# Altium schematic sheet ESP_Grow_Main_Rev_A_2_3V_5V.SchDoc(ESP_Grow_Main_Rev_A_2_3V_5V)
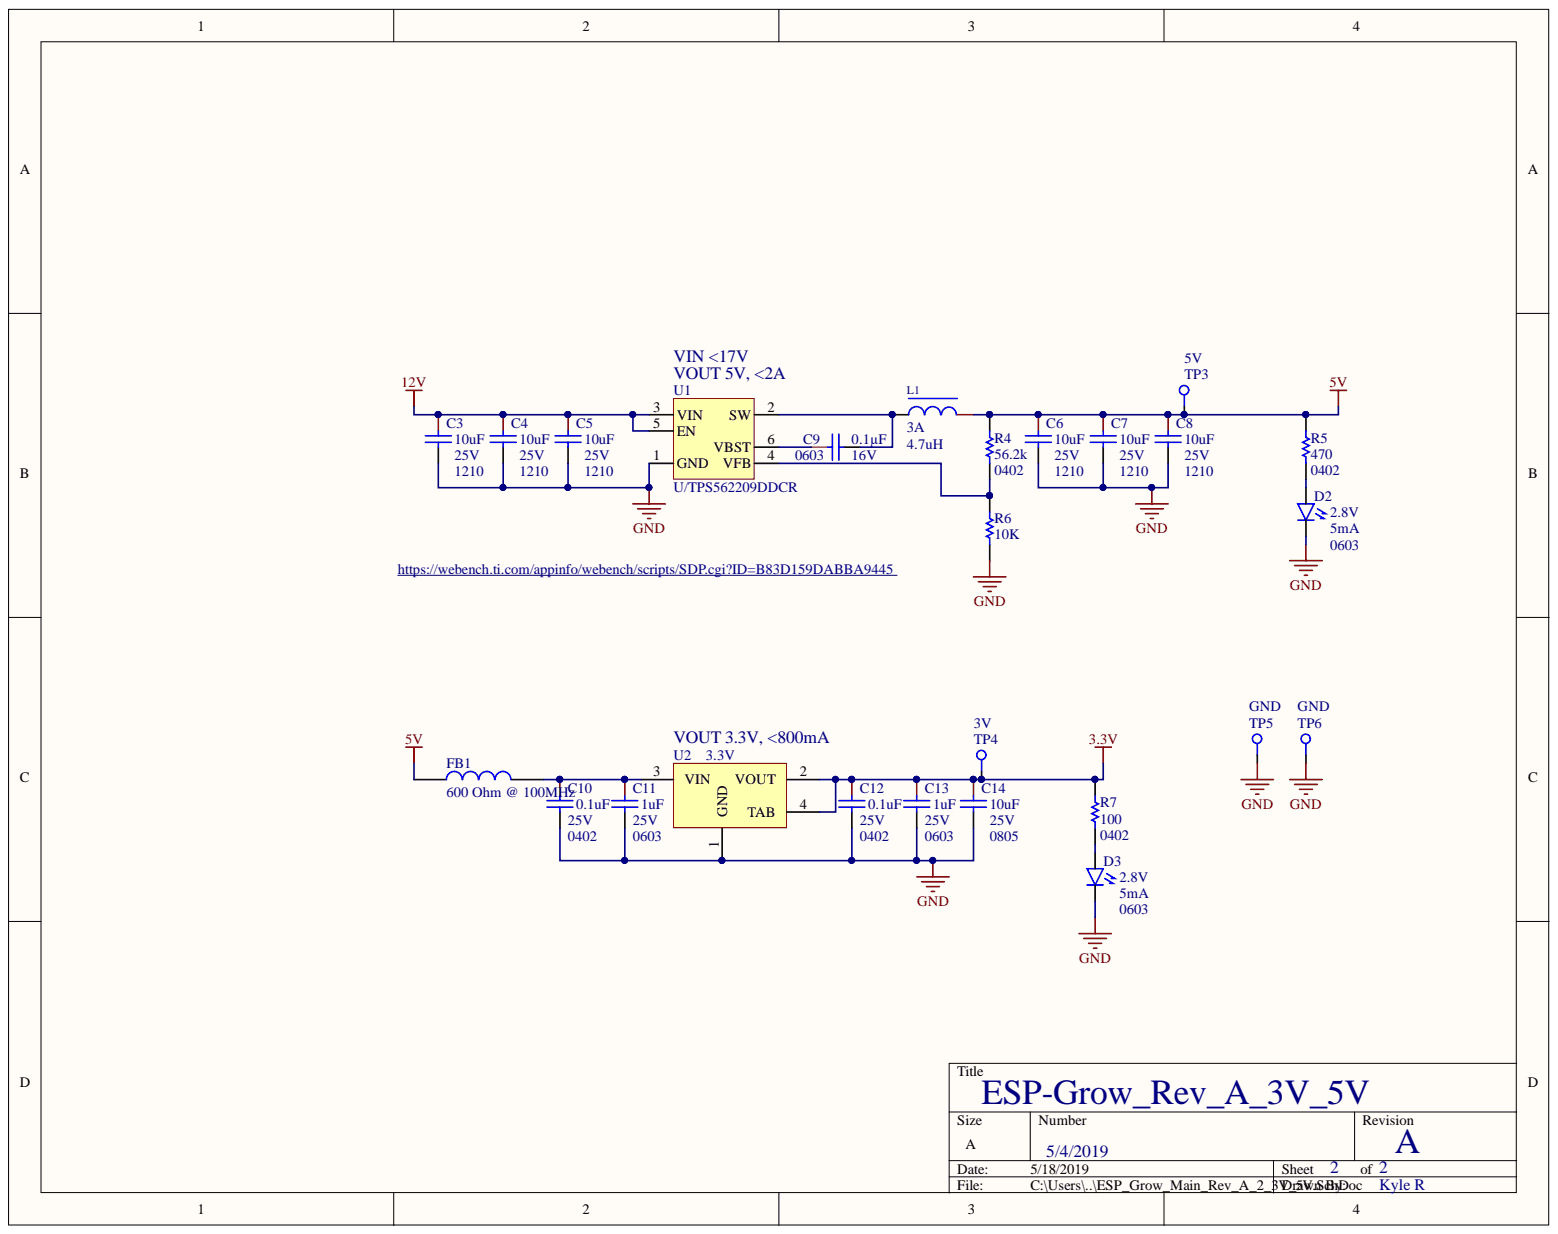
[diagram p0: ESP_Grow_Main_Rev_A_2_3V_5V.SchDoc]

## Parts
C3  10uF  Voltage Rated: 25V  Package / Case: 1210  [CAPC1210(3225)_N]
C4  10uF  Voltage Rated: 25V  Package / Case: 1210  [CAPC1210(3225)_N]
C5  10uF  Voltage Rated: 25V  Package / Case: 1210  [CAPC1210(3225)_N]
C6  10uF  Voltage Rated: 25V  Package / Case: 1210  [CAPC1210(3225)_N]
C7  10uF  Voltage Rated: 25V  Package / Case: 1210  [CAPC1210(3225)_N]
C8  10uF  Voltage Rated: 25V  Package / Case: 1210  [CAPC1210(3225)_N]
C9  Voltage - Rated: 16V  Package / Case: 0603  Capacitance: 0.1µF  [CAPC0603(1608)_L]  (hidden comment: 0.1uF)
C10  0.1uF  Voltage - Rated: 25V  Package / Case: 0402  [CAPC0402(1005)_N]
C11  1uF  Voltage - Rated: 25V  Package / Case: 0603  [CAPC0603(1608)_N]
C12  0.1uF  Voltage - Rated: 25V  Package / Case: 0402  [CAPC0402(1005)_N]
C13  1uF  Voltage - Rated: 25V  Package / Case: 0603  [CAPC0603(1608)_N]
C14  10uF  Voltage - Rated: 25V  Package / Case: 0805  [CAPC0805(2012)_L]
D2  Voltage - Forward (Vf) (Typ): 2.8V  Package / Case: 0603  Current - Test: 5mA  [D/0603]  (hidden comment: D/LEDB/0603)
D3  Voltage - Forward (Vf) (Typ): 2.8V  Package / Case: 0603  Current - Test: 5mA  [D/0603]  (hidden comment: D/LEDB/0603)
FB1  600 Ohm @ 100MHz  [RESC0805(2012)_N]
L1  4.7uH  Current Rating (Amps): 3A  [INDP6060X45N]
R4  Supplier Device Package: 0402  Resistance (Ohms): 56.2k  [RESC0402(1005)_N]  (hidden comment: 56.2K 1%)
R5  470  Supplier Device Package: 0402  [RESC0402(1005)_N]
R6  10K  [RESC0402(1005)_N]
R7  100  Supplier Device Package: 0402  [RESC0402(1005)_N]
TP3  5V  [Pogo-Testpoint]  (no BOM)
TP4  3V  [Pogo-Testpoint]  (no BOM)
TP5  GND  [Pogo-Testpoint]  (no BOM)
TP6  GND  [Pogo-Testpoint]  (no BOM)
U1  U/TPS562209DDCR  [SOT-23]
U2  3.3V  [DSO-G3]

## Nets
3.3V: C12.1 C13.1 C14.1 R7.2 TP4.1 U2.2(VOUT) U2.4(TAB)
5V: C6.1 C7.1 C8.1 FB1.1 L1.1 R4.2 R5.2 TP3.1
12V: C3.1 C4.1 C5.1 U1.3(VIN) U1.5(EN)
GND: C3.2 C4.2 C5.2 C6.2 C7.2 C8.2 C10.2 C11.2 C12.2 C13.2 C14.2 D2.1 D3.1 R6.1 TP5.1 TP6.1 U1.1(GND) U2.1(GND)
NetC9_1: C9.1 U1.6(VBST)
NetC9_2: C9.2 L1.2 U1.2(SW)
NetC10_1: C10.1 C11.1 FB1.2 U2.3(VIN)
NetD2_2: D2.2 R5.1
NetD3_2: D3.2 R7.1
NetR4_1: R4.1 R6.2 U1.4(VFB)

## Library data of the parts
C3-C8 = CAP CER 10UF 25V JB 1210: 10uF 1210 25V; Manufacturer Part Number: C3225JB1E106K250AA; Manufacturer: TDK Corporation; Tolerance: ±10%; Temperature Coefficient: JB; Notification: Current market demand for these product types have resulted in fluctuating and extending lead times. Lead times may differ.; Voltage - Rated: 25V; Thickness (Max): 0.110" (2.80mm); Supplier Part Number 1: 445-11850-1-ND; Supplier 1: Digi-Key; Stock 1: 19014; Size / Dimension: 0.126" L x 0.098" W (3.20mm x 2.50mm); RoHS: RoHS Compliant; Ratings: -; Pricing 1: 1=0.74, 10=0.525, 100=0.3454, 500=0.25588 (USD); Part Status: Active; Packaging: Cut Tape (CT); Operating Temperature: -25°C ~ 85°C; Mounting Type: Surface Mount, MLCC; Library Name: OLogic-Passive.IntLib; Lead Style: -; Lead Spacing: -; Height - Seated (Max): -; Features: Low ESL; Failure Rate: -; ComponentLink1URL: https://product.tdk.com/info/en/catalog/datasheets/mlcc_commercial_general_en.pdf; ComponentLink1Description: https://product.tdk.com/info/en/catalog/datasheets/mlcc_commercial_general_en.pdf; Component Kind: Standard; Category: Capacitors; Applications: General Purpose
C9 = CAP CER 0.1UF 16V 10% X7R 0603: 0.1uF 0603 16V; Manufacturer Part Number: C0603C104K4RACTU; Manufacturer: Kemet; Tolerance: ±10%; Temperature Coefficient: X7R; Thickness (Max): 0.034" (0.87mm); Supplier Part Number 1: 399-1096-2-ND; Supplier 1: Digi-Key; Stock 1: 2360000; Size / Dimension: 0.063" L x 0.031" W (1.60mm x 0.80mm); RoHS: RoHS Compliant; Ratings: -; Pricing 1: 4000=0.00414, 8000=0.00378, 12000=0.0036, 28000=0.00342, 100000=0.00248 (USD); Packaging: Tape & Reel (TR); Operating Temperature: -55°C ~ 125°C; Mounting Type: Surface Mount, MLCC; Library Name: OLogic-Passive.IntLib; Lead Style: -; Lead Spacing: -; Height - Seated (Max): -; Features: -; ComponentLink1URL: http://www.kemet.com/datasheets&C0603C104K4RACTU; ComponentLink1Description: http://www.kemet.com/datasheets&C0603C104K4RACTU; Component Kind: Standard; Category: Capacitors; Applications: General Purpose
C10 C12 = CAP CER 0.1UF 25V 10% X5R 0402: 0.1uF 0402 25V; Manufacturer Part Number: TMK105BJ104KV-F; Manufacturer: Taiyo Yuden; Tolerance: ±10%; Temperature Coefficient: X5R; Notification: Current market demand for these product types have resulted in fluctuating and extending lead times. Lead times may differ.; Thickness (Max): 0.022" (0.55mm); Supplier Part Number 1: 587-1456-1-ND; Supplier 1: Digi-Key; Stock 1: 5172635; Size / Dimension: 0.039" L x 0.020" W (1.00mm x 0.50mm); RoHS: RoHS Compliant; Ratings: -; Pricing 1: 1=0.1, 10=0.043, 100=0.019, 500=0.0136, 1000=0.01069, 2500=0.00972, 5000=0.00894 (USD); Part Status: Active; Packaging: Cut Tape (CT); Operating Temperature: -55°C ~ 85°C; Mounting Type: Surface Mount, MLCC; Library Name: OLogic-Passive.IntLib; Lead Style: -; Lead Spacing: -; Height - Seated (Max): -; Features: -; ComponentLink1URL: http://ds.yuden.co.jp/TYCOMPAS/ut/detail.do?productNo=TMK105BJ104KV-F&fileName=TMK105BJ104KV-F_SS&mode=specSheetDownload; ComponentLink1Description: http://ds.yuden.co.jp/TYCOMPAS/ut/detail.do?productNo=TMK105BJ104KV-F&fileName=TMK105BJ104KV-F_SS&mode=specSheetDownload; Component Kind: Standard; Category: Capacitors; Applications: General Purpose
C11 C13 = CAP CER 1UF 25V 10% X7R 0603: 1uF 0603 25V; Manufacturer Part Number: CGA3E1X7R1E105K080AC; Manufacturer: TDK Corporation; Tolerance: ±10%; Temperature Coefficient: X7R; Thickness (Max): 0.035" (0.90mm); Supplier Part Number 1: 445-6931-1-ND; Supplier 1: Digi-Key; Stock 1: 360610; Size / Dimension: 0.063" L x 0.032" W (1.60mm x 0.80mm); RoHS: RoHS Compliant; Ratings: AEC-Q200; Pricing 1: 1=0.2, 10=0.135, 100=0.0686, 500=0.04696, 1000=0.04154 (USD); Part Status: Active; Packaging: Cut Tape (CT); Operating Temperature: -55°C ~ 125°C; Mounting Type: Surface Mount, MLCC; Library Name: OLogic-Passive.IntLib; Lead Style: -; Lead Spacing: -; Height - Seated (Max): -; Features: -; Failure Rate: -; ComponentLink1URL: https://product.tdk.com/info/en/catalog/datasheets/mlcc_automotive_general_en.pdf; ComponentLink1Description: https://product.tdk.com/info/en/catalog/datasheets/mlcc_automotive_general_en.pdf; Component Kind: Standard; Category: Capacitors; Applications: Automotive
C14 = CAP CER 10UF 25V X6S 0805: 10UF 25V X6R 0805; Manufacturer Part Number: GRM21BC81E106ME51L; Manufacturer: Murata Electronics North America; Tolerance: ±20%; Temperature Coefficient: X6S; Notification: Current market demand for these product types have resulted in fluctuating and extending lead times. Lead times may differ.; Thickness (Max): 0.055" (1.40mm); Supplier Part Number 1: 490-10503-1-ND; Supplier 1: Digi-Key; Stock 1: 23735; Size / Dimension: 0.079" L x 0.049" W (2.00mm x 1.25mm); RoHS: RoHS Compliant; Ratings: -; Pricing 1: 1=0.29, 10=0.199, 100=0.1009, 500=0.06906, 1000=0.0611 (USD); Part Status: Active; Packaging: Cut Tape (CT); Operating Temperature: -55°C ~ 105°C; Mounting Type: Surface Mount, MLCC; Library Name: OLogic-Passive.IntLib; Lead Style: -; Lead Spacing: -; Height - Seated (Max): -; Features: -; ComponentLink1URL: http://search.murata.co.jp/Ceramy/image/img/A01X/G101/ENG/GRM21BC81E106ME51-01.pdf; ComponentLink1Description: http://search.murata.co.jp/Ceramy/image/img/A01X/G101/ENG/GRM21BC81E106ME51-01.pdf; Component Kind: Standard; Category: Capacitors; Applications: General Purpose
FB1 = FERRITE 600 OHM 2.0A 0805: 600 OHM 0805 2.0A; Manufacturer Part Number: 742792040; Manufacturer: Wurth Electronics Inc; Filter Type: Differential Mode - Single; DC Resistance (DCR): 150 mOhm Max; Current Rating: 2A; Supplier Part Number 1: 732-1620-1-ND; Supplier 1: Digi-Key; Stock 1: 34670; Size / Dimension: 0.079" L x 0.047" W (2.00mm x 1.20mm); RoHS: RoHS Compliant; Pricing 1: 1=0.24, 50=0.2344, 100=0.2156, 500=0.20624, 1000=0.19687, 2000=0.1875 (USD); Packaging: Cut Tape (CT); Package / Case: 0805 (2012 Metric); Mounting Type: Surface Mount; Library Name: OLogic-Passive.IntLib; Impedance @ Frequency: 600 Ohm @ 100MHz; Height (Max): 0.043" (1.10mm); ComponentLink1URL: http://katalog.we-online.de/pbs/datasheet/742792040.pdf; ComponentLink1Description: http://katalog.we-online.de/pbs/datasheet/742792040.pdf; Component Kind: Standard; Category: Filters; Creator: osman
L1 = L/4.7uH/3A: FIXED IND 4.7UH 3A 37.6 MOHM SMD; Manufacturer Part Number: SRN6045-4R7Y; Shielding: Semi-Shielded; DC Resistance (DCR): 37.6mOhm Max; Current Saturation (Amps): 4A; Size / Dimension: 0.236" L x 0.236" W (6.00mm x 6.00mm); Inductance: 4.7uH; Datasheet: https://www.bourns.com/data/global/pdfs/SRN6045.pdf; Component Kind: Standard; Library Name: Kyle_Lib_04-20-19.IntLib
R4 = RES 56.2K OHM 1% 1/10W 0402: 56.2K 1% 0402; Manufacturer Part Number: ERJ-2RKF5622X; Manufacturer: Panasonic Electronic Components; Temperature Coefficient: ±100ppm/°C; Power (Watts): 0.1W, 1/10W; Tolerance: ±1%; Supplier Part Number 1: P56.2KLCT-ND; Supplier 1: Digi-Key; Stock 1: 222789; Size / Dimension: 0.039" L x 0.020" W (1.00mm x 0.50mm); RoHS: RoHS Compliant; Pricing 1: 1=0.1, 50=0.0124, 100=0.0091, 250=0.00692, 500=0.00554, 1000=0.00408, 5000=0.00293 (USD); Part Status: Active; Packaging: Cut Tape (CT); Package / Case: 0402; Operating Temperature: -55°C ~ 155°C; Number of Terminations: 2; Library Name: OLogic-Passive.IntLib; Height: 0.016" (0.40mm); Features: Automotive AEC-Q200; Failure Rate: -; Composition: Thick Film; ComponentLink1URL: http://industrial.panasonic.com/www-cgi/jvcr13pz.cgi?E+PZ+3+AOA0002+ERJ2RKF5622X+7+WW; ComponentLink1Description: http://industrial.panasonic.com/www-cgi/jvcr13pz.cgi?E+PZ+3+AOA0002+ERJ2RKF5622X+7+WW; Component Kind: Standard; Category: Resistors
R5 = RES 470 OHM 1% 1/10W 0402: 470 0402; Manufacturer Part Number: ERJ-2RKF4700X; Manufacturer: Panasonic Electronic Components; Tolerance: ±1%; Temperature Coefficient: ±100ppm/°C; Power (Watts): 0.1W, 1/10W; Supplier Part Number 1: P470LCT-ND; Supplier 1: Digi-Key; Stock 1: 342632; Size / Dimension: 0.039" L x 0.020" W (1.00mm x 0.50mm); RoHS: RoHS Compliant; Resistance (Ohms): 470; Pricing 1: 1=0.1, 10=0.025, 25=0.018, 50=0.0136, 100=0.01, 250=0.00764, 500=0.00612, 1000=0.00451, 5000=0.00323 (USD); Part Status: Active; Packaging: Cut Tape (CT); Package / Case: 0402; Operating Temperature: -55°C ~ 155°C; Number of Terminations: 2; Library Name: OLogic-Passive.IntLib; Height - Seated (Max): 0.016" (0.40mm); Features: Automotive AEC-Q200; Failure Rate: -; Composition: Thick Film; ComponentLink1URL: https://industrial.panasonic.com/cdbs/www-data/pdf/RDA0000/AOA0000C283.pdf; ComponentLink1Description: https://industrial.panasonic.com/cdbs/www-data/pdf/RDA0000/AOA0000C283.pdf; Component Kind: Standard; Category: Resistors
R6 = RES 10K OHM 1% 1/16W 0402: 10K 0402; Manufacturer Part Number: RC1005F103CS; Manufacturer: Samsung Electro-Mechanics; Tolerance: ±1%; Temperature Coefficient: ±100ppm/°C; Power (Watts): 0.063W, 1/16W; Supplier Part Number 1: 1276-3431-1-ND; Supplier Device Package: 0402; Supplier 1: Digi-Key; Stock 1: 25834562; Size / Dimension: 0.039" L x 0.020" W (1.00mm x 0.50mm); RoHS: RoHS Compliant; Pricing 1: 1=0.1, 10=0.021, 100=0.0086, 1000=0.00385, 2500=0.00334, 5000=0.00276 (USD); Part Status: Active; Packaging: Cut Tape (CT); Package / Case: 0402 (1005 Metric); Operating Temperature: -55°C ~ 155°C; Library Name: OLogic-Passive.IntLib; Height - Seated (Max): 0.016" (0.40mm); Features: Moisture Resistant; Failure Rate: -; Composition: Thick Film; ComponentLink1URL: http://www.samsungsem.com/kr/support/product-search/chip-resistor/RC1005F103CS.jsp; ComponentLink1Description: http://www.samsungsem.com/kr/support/product-search/chip-resistor/RC1005F103CS.jsp; Component Kind: Standard; Category: Resistors
R7 = RES 100 OHM 1% 1/16W 0402: 100 0402; Manufacturer Part Number: RC0402FR-07100RL; Manufacturer: Yageo; Tolerance: ±1%; Temperature Coefficient: ±100ppm/°C; Power (Watts): 0.063W, 1/16W; Supplier Part Number 1: 311-100LRCT-ND; Supplier 1: Digi-Key; Stock 1: 14557677; Size / Dimension: 0.039" L x 0.020" W (1.00mm x 0.50mm); RoHS: RoHS Compliant; Pricing 1: 1=0.1, 10=0.014, 100=0.0056, 1000=0.00253, 2500=0.0022, 5000=0.00181 (USD); Part Status: Active; Packaging: Cut Tape (CT); Package / Case: 0402 (1005 Metric); Operating Temperature: -55°C ~ 155°C; Library Name: OLogic-Passive.IntLib; Height - Seated (Max): 0.016" (0.40mm); Features: Moisture Resistant; Failure Rate: -; Composition: Thick Film; ComponentLink1URL: http://www.yageo.com/documents/recent/PYu-RC_Group_51_RoHS_L_8.pdf; ComponentLink1Description: http://www.yageo.com/documents/recent/PYu-RC_Group_51_RoHS_L_8.pdf; Component Kind: Standard; Category: Resistors
TP3-TP6 = CMP-001-0557-03: Test Point- Current one; Pin Count: 1; Designator: TestPoint; Component Name: TestPoint; Library Name: OLogic Nexus Vault
U1 = U/TPS562209DDCR: IC REG BUCK ADJ 2A TSOT23-6; Voltage - Output (Min/Fixed): 0.765V; Voltage - Output (Max): 7V; Voltage - Input (Min): 4.5V; Voltage - Input (Max): 17V; Frequency - Switching: 650KHZ; Topology: Buck; Supplier Device Package: TSOT-23-6; Manufacturer Part Number: TPS562209DDCR; Current - Output: 2A; Component Kind: Standard; Library Name: Kyle_Lib_04-20-19.IntLib
U2 = LD1117S33: 3.3 volt fixed regulator, 800mA; Supplier Part Number 1: 497-1242-1-ND; Supplier 1: Digi-Key; Component Kind: Standard; Library Name: OLogic-Reg-Convert.IntLib
D2 D3 = D/LEDB/0603: Supplier Part Number 1: 1080-1562-6-ND; Supplier 1: Digi-Key; Datasheet: https://everlightamericas.com/index.php?controller=attachment&id_attachment=3217; Component Kind: Standard; Library Name: Kyle_Lib_04-20-19.IntLib
